# Altium schematic sheet VI.595X5.V1.1.SchDoc
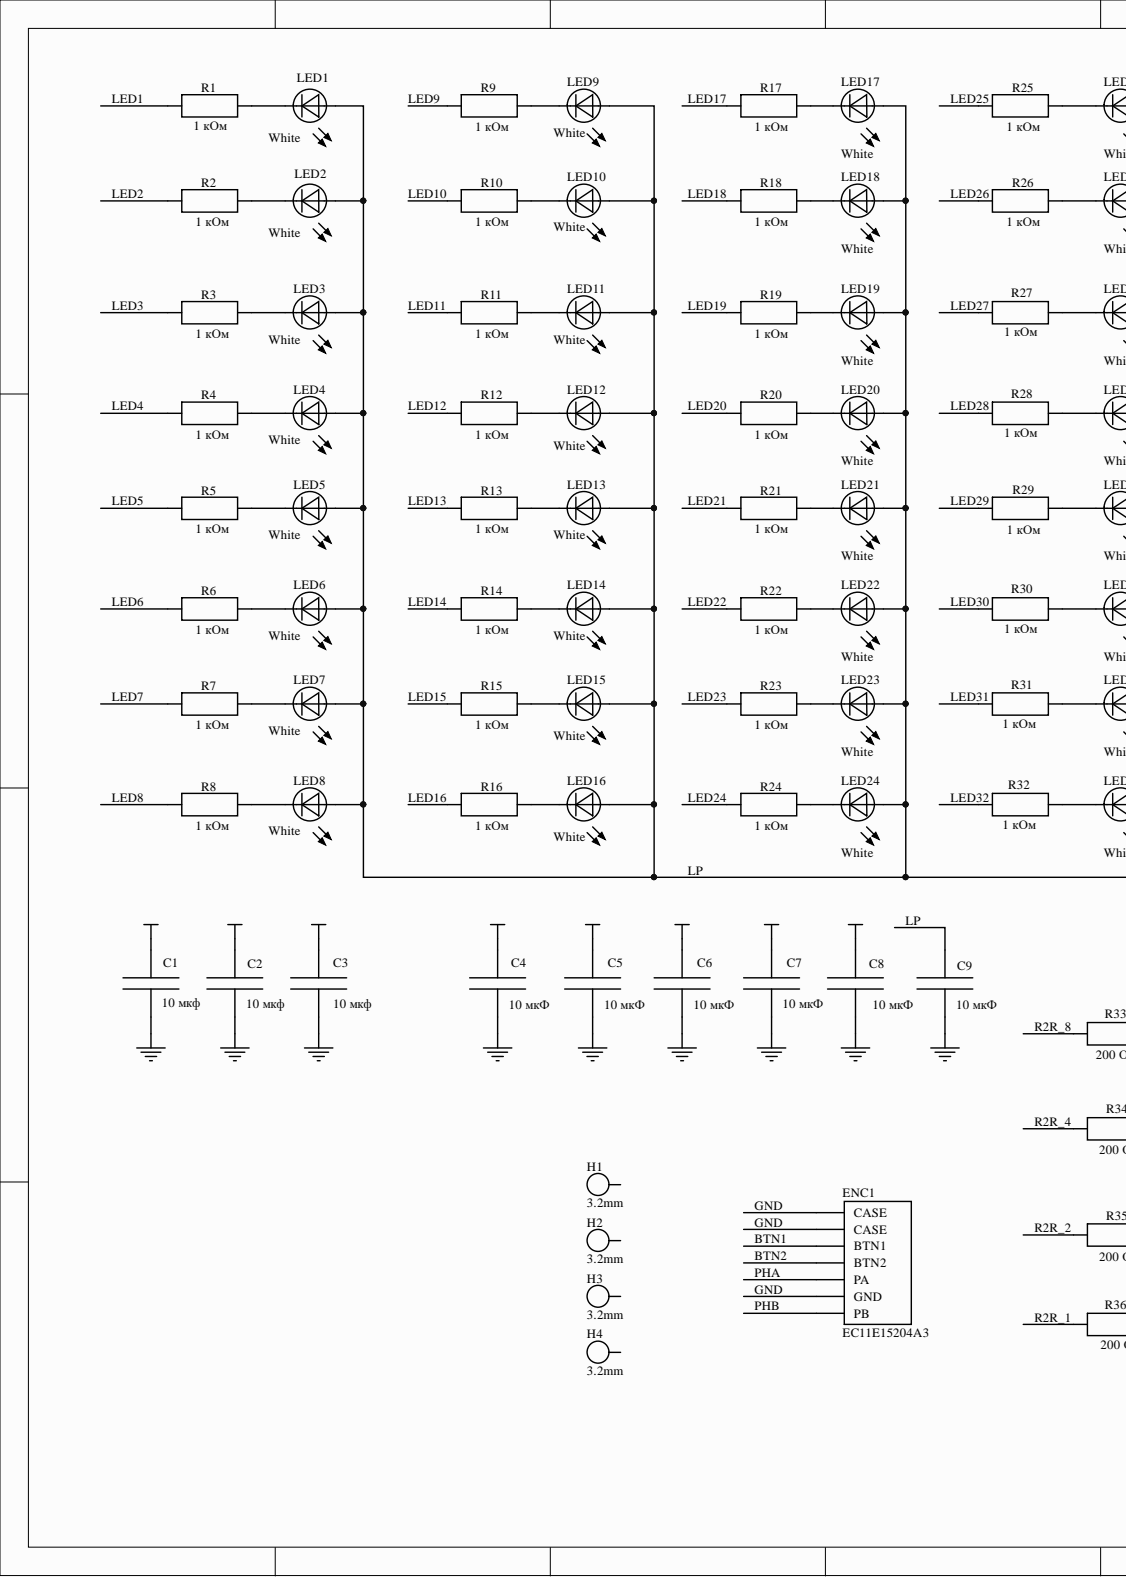
[diagram p0: VI.595X5.V1.1.SchDoc, left]
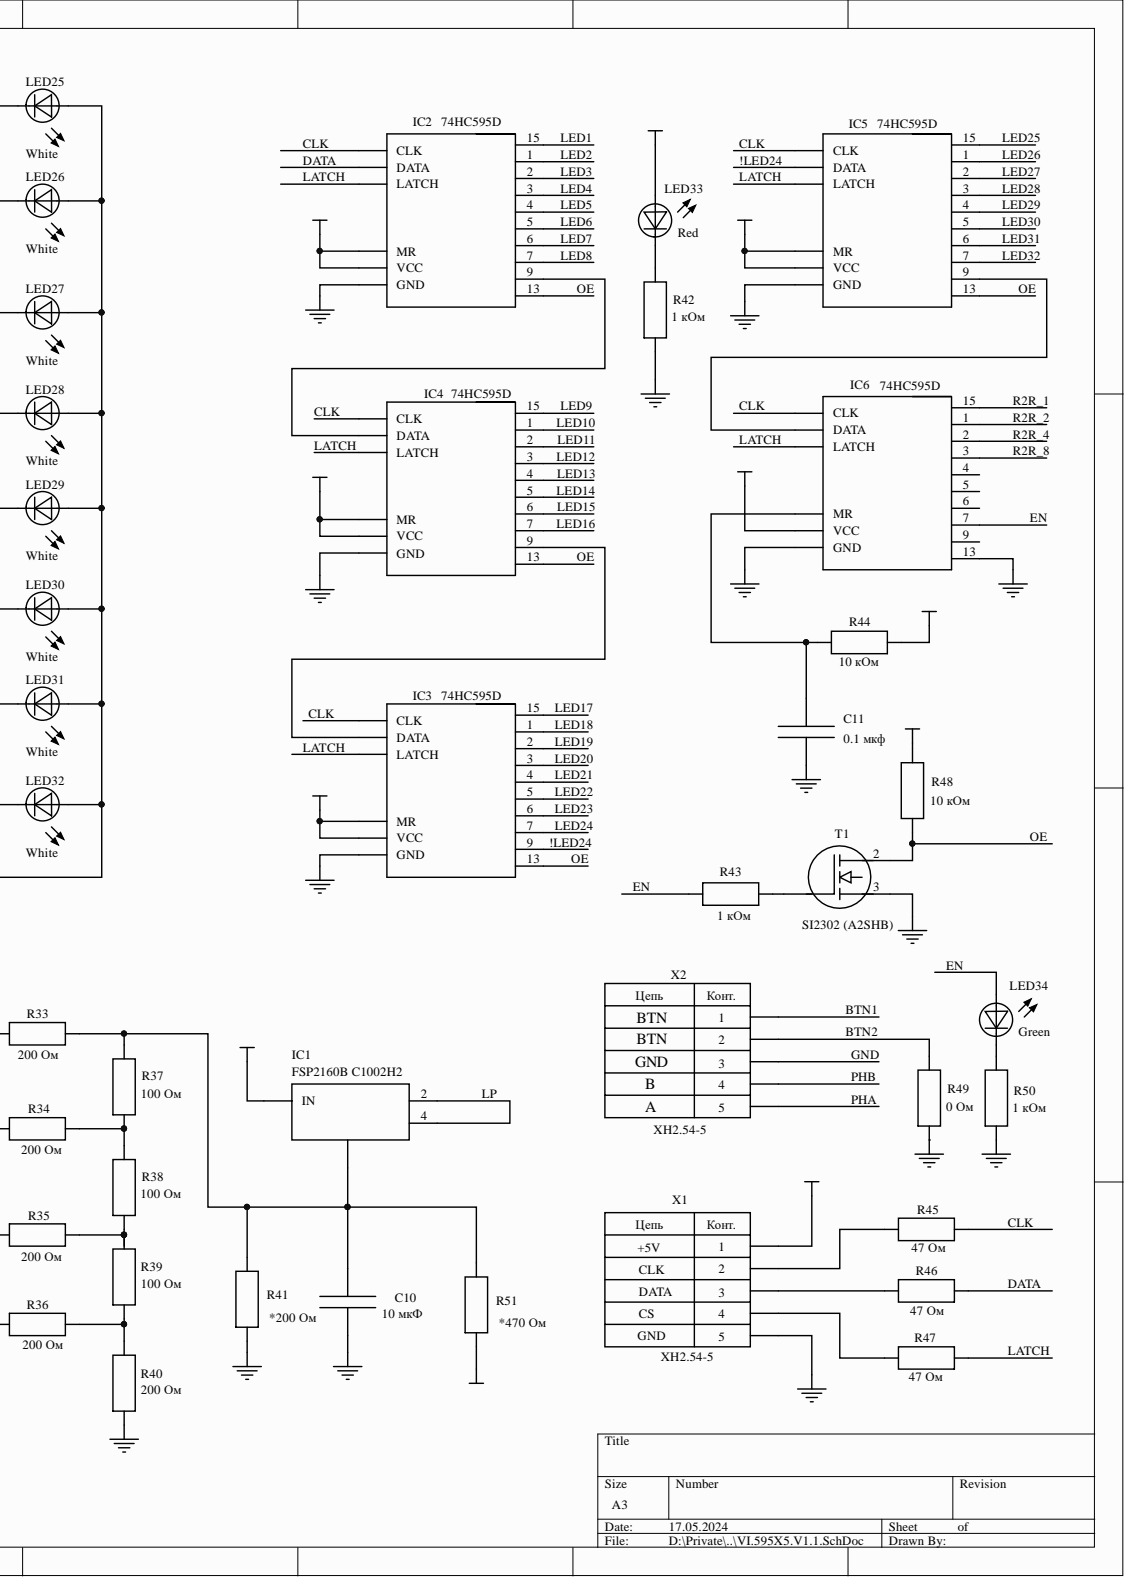
[diagram p1: VI.595X5.V1.1.SchDoc, right]

## Parts
C1  10 мкф  [Конденсатор SMD Case 1206]
C2  10 мкф  [Конденсатор SMD Case 1206]
C3  10 мкф  [Конденсатор SMD Case 1206]
C4  10 мкФ  [Конденсатор SMD Case 1206]
C5  10 мкФ  [Конденсатор SMD Case 1206]
C6  10 мкФ  [Конденсатор SMD Case 1206]
C7  10 мкФ  [Конденсатор SMD Case 1206]
C8  10 мкФ  [Конденсатор SMD Case 1206]
C9  10 мкФ  [Конденсатор SMD Case 1206]
C10  10 мкФ  [Конденсатор SMD Case 1206]
C11  0.1 мкф  [Конденсатор SMD Case 1206]
ENC1  EC11E15204A3  [Encoder]
H1  3.2mm  [Отверстие 3,2]
H2  3.2mm  [Отверстие 3,2]
H3  3.2mm  [Отверстие 3,2]
H4  3.2mm  [Отверстие 3,2]
IC1  FSP2160B C1002H2  [SOT-223]
IC2  74HC595D  [SO-16]
IC3  74HC595D  [SO-16]
IC4  74HC595D  [SO-16]
IC5  74HC595D  [SO-16]
IC6  74HC595D  [SO-16]
LED1  White  [Светодиод  5x2 mm]
LED2  White  [Светодиод  5x2 mm]
LED3  White  [Светодиод  5x2 mm]
LED4  White  [Светодиод  5x2 mm]
LED5  White  [Светодиод  5x2 mm]
LED6  White  [Светодиод  5x2 mm]
LED7  White  [Светодиод  5x2 mm]
LED8  White  [Светодиод  5x2 mm]
LED9  White  [Светодиод  5x2 mm]
LED10  White  [Светодиод  5x2 mm]
LED11  White  [Светодиод  5x2 mm]
LED12  White  [Светодиод  5x2 mm]
LED13  White  [Светодиод  5x2 mm]
LED14  White  [Светодиод  5x2 mm]
LED15  White  [Светодиод  5x2 mm]
LED16  White  [Светодиод  5x2 mm]
LED17  White  [Светодиод  5x2 mm]
LED18  White  [Светодиод  5x2 mm]
LED19  White  [Светодиод  5x2 mm]
LED20  White  [Светодиод  5x2 mm]
LED21  White  [Светодиод  5x2 mm]
LED22  White  [Светодиод  5x2 mm]
LED23  White  [Светодиод  5x2 mm]
LED24  White  [Светодиод  5x2 mm]
LED25  White  [Светодиод  5x2 mm]
LED26  White  [Светодиод  5x2 mm]
LED27  White  [Светодиод  5x2 mm]
LED28  White  [Светодиод  5x2 mm]
LED29  White  [Светодиод  5x2 mm]
LED30  White  [Светодиод  5x2 mm]
LED31  White  [Светодиод  5x2 mm]
LED32  White  [Светодиод  5x2 mm]
LED33  Red  [SMD 1206 Светодиод ВАЙТ]
LED34  Green  [SMD 1206 Светодиод ВАЙТ]
R1  1 кОм  [SMD 0805 Резистор]
R2  1 кОм  [SMD 0805 Резистор]
R3  1 кОм  [SMD 0805 Резистор]
R4  1 кОм  [SMD 0805 Резистор]
R5  1 кОм  [SMD 0805 Резистор]
R6  1 кОм  [SMD 0805 Резистор]
R7  1 кОм  [SMD 0805 Резистор]
R8  1 кОм  [SMD 0805 Резистор]
R9  1 кОм  [SMD 0805 Резистор]
R10  1 кОм  [SMD 0805 Резистор]
R11  1 кОм  [SMD 0805 Резистор]
R12  1 кОм  [SMD 0805 Резистор]
R13  1 кОм  [SMD 0805 Резистор]
R14  1 кОм  [SMD 0805 Резистор]
R15  1 кОм  [SMD 0805 Резистор]
R16  1 кОм  [SMD 0805 Резистор]
R17  1 кОм  [SMD 0805 Резистор]
R18  1 кОм  [SMD 0805 Резистор]
R19  1 кОм  [SMD 0805 Резистор]
R20  1 кОм  [SMD 0805 Резистор]
R21  1 кОм  [SMD 0805 Резистор]
R22  1 кОм  [SMD 0805 Резистор]
R23  1 кОм  [SMD 0805 Резистор]
R24  1 кОм  [SMD 0805 Резистор]
R25  1 кОм  [SMD 0805 Резистор]
R26  1 кОм  [SMD 0805 Резистор]
R27  1 кОм  [SMD 0805 Резистор]
R28  1 кОм  [SMD 0805 Резистор]
R29  1 кОм  [SMD 0805 Резистор]
R30  1 кОм  [SMD 0805 Резистор]
R31  1 кОм  [SMD 0805 Резистор]
R32  1 кОм  [SMD 0805 Резистор]
R33  200 Ом  [SMD 0805 Резистор]
R34  200 Ом  [SMD 0805 Резистор]
R35  200 Ом  [SMD 0805 Резистор]
R36  200 Ом  [SMD 0805 Резистор]
R37  100 Ом  [SMD 0805 Резистор]
R38  100 Ом  [SMD 0805 Резистор]
R39  100 Ом  [SMD 0805 Резистор]
R40  200 Ом  [SMD 0805 Резистор]
R41  *200 Ом  [SMD 0805 Резистор]
R42  1 кОм  [SMD 0805 Резистор]
R43  1 кОм  [SMD 0805 Резистор]
R44  10 кОм  [SMD 0805 Резистор]
R45  47 Ом  [SMD 0805 Резистор]
R46  47 Ом  [SMD 0805 Резистор]
R47  47 Ом  [SMD 0805 Резистор]
R48  10 кОм  [SMD 0805 Резистор]
R49  0 Ом  [SMD 0805 Резистор]
R50  1 кОм  [SMD 0805 Резистор]
R51  *470 Ом  [SMD 0805 Резистор]
T1  SI2302 (A2SHB)  [SMD SOT-23 Транзистор 3 pin]
X1  XH2.54-5  [(1x5)pin XH 2.54 Header]
X2  XH2.54-5  [(1x5)pin XH 2.54 Header]

## Nets
VCC: C1.1 C2.1 C3.1 C4.1 C5.1 C6.1 C7.1 C8.1 IC1.3(IN) IC2.10(MR) IC2.16(VCC) IC3.10(MR) IC3.16(VCC) IC4.10(MR) IC4.16(VCC) IC5.10(MR) IC5.16(VCC) IC6.16(VCC) LED33.1 R44.1 R48.1 R51.1 X1.1
!LED24: IC3.9 IC5.14(DATA)
BTN1: ENC1.3(BTN1) X2.1
BTN2: ENC1.4(BTN2) R49.2 X2.2
CLK: IC2.11(CLK) IC3.11(CLK) IC4.11(CLK) IC5.11(CLK) IC6.11(CLK) R45.1
DATA: IC2.14(DATA) R46.1
EN: IC6.7 LED34.1 R43.2
GND: C1.2 C2.2 C3.2 C4.2 C5.2 C6.2 C7.2 C8.2 C9.2 C10.2 C11.2 ENC1.1(CASE) ENC1.2(CASE) ENC1.6(GND) IC2.8(GND) IC3.8(GND) IC4.8(GND) IC5.8(GND) IC6.8(GND) IC6.13 R40.1 R41.1 R42.1 R49.1 R50.1 T1.3 X1.5 X2.3
LATCH: IC2.12(LATCH) IC3.12(LATCH) IC4.12(LATCH) IC5.12(LATCH) IC6.12(LATCH) R47.1
LED1: IC2.15 R1.1
LED2: IC2.1 R2.1
LED3: IC2.2 R3.1
LED4: IC2.3 R4.1
LED5: IC2.4 R5.1
LED6: IC2.5 R6.1
LED7: IC2.6 R7.1
LED8: IC2.7 R8.1
LED9: IC4.15 R9.1
LED10: IC4.1 R10.1
LED11: IC4.2 R11.1
LED12: IC4.3 R12.1
LED13: IC4.4 R13.1
LED14: IC4.5 R14.1
LED15: IC4.6 R15.1
LED16: IC4.7 R16.1
LED17: IC3.15 R17.1
LED18: IC3.1 R18.1
LED19: IC3.2 R19.1
LED20: IC3.3 R20.1
LED21: IC3.4 R21.1
LED22: IC3.5 R22.1
LED23: IC3.6 R23.1
LED24: IC3.7 R24.1
LED25: IC5.15 R25.1
LED26: IC5.1 R26.1
LED27: IC5.2 R27.1
LED28: IC5.3 R28.1
LED29: IC5.4 R29.1
LED30: IC5.5 R30.1
LED31: IC5.6 R31.1
LED32: IC5.7 R32.1
LP: C9.1 IC1.2 IC1.4 LED1.1 LED2.1 LED3.1 LED4.1 LED5.1 LED6.1 LED7.1 LED8.1 LED9.1 LED10.1 LED11.1 LED12.1 LED13.1 LED14.1 LED15.1 LED16.1 LED17.1 LED18.1 LED19.1 LED20.1 LED21.1 LED22.1 LED23.1 LED24.1 LED25.1 LED26.1 LED27.1 LED28.1 LED29.1 LED30.1 LED31.1 LED32.1
OE: IC2.13 IC3.13 IC4.13 IC5.13 R48.2 T1.2
PHA: ENC1.5(PA) X2.5
PHB: ENC1.7(PB) X2.4
R2R_1: IC6.15 R36.1
R2R_2: IC6.1 R35.1
R2R_4: IC6.2 R34.1
R2R_8: IC6.3 R33.1
NetC10_1: C10.1 IC1.1 R33.2 R37.2 R41.2 R51.2
NetC11_1: C11.1 IC6.10(MR) R44.2
NetIC2_9: IC2.9 IC4.14(DATA)
NetIC3_14: IC3.14(DATA) IC4.9
NetIC5_9: IC5.9 IC6.14(DATA)
NetLED1_2: LED1.2 R1.2
NetLED2_2: LED2.2 R2.2
NetLED3_2: LED3.2 R3.2
NetLED4_2: LED4.2 R4.2
NetLED5_2: LED5.2 R5.2
NetLED6_2: LED6.2 R6.2
NetLED7_2: LED7.2 R7.2
NetLED8_2: LED8.2 R8.2
NetLED9_2: LED9.2 R9.2
NetLED10_2: LED10.2 R10.2
NetLED11_2: LED11.2 R11.2
NetLED12_2: LED12.2 R12.2
NetLED13_2: LED13.2 R13.2
NetLED14_2: LED14.2 R14.2
NetLED15_2: LED15.2 R15.2
NetLED16_2: LED16.2 R16.2
NetLED17_2: LED17.2 R17.2
NetLED18_2: LED18.2 R18.2
NetLED19_2: LED19.2 R19.2
NetLED20_2: LED20.2 R20.2
NetLED21_2: LED21.2 R21.2
NetLED22_2: LED22.2 R22.2
NetLED23_2: LED23.2 R23.2
NetLED24_2: LED24.2 R24.2
NetLED25_2: LED25.2 R25.2
NetLED26_2: LED26.2 R26.2
NetLED27_2: LED27.2 R27.2
NetLED28_2: LED28.2 R28.2
NetLED29_2: LED29.2 R29.2
NetLED30_2: LED30.2 R30.2
NetLED31_2: LED31.2 R31.2
NetLED32_2: LED32.2 R32.2
NetLED33_2: LED33.2 R42.2
NetLED34_2: LED34.2 R50.2
NetR34_2: R34.2 R37.1 R38.2
NetR35_2: R35.2 R38.1 R39.2
NetR36_2: R36.2 R39.1 R40.2
NetR43_1: R43.1 T1.1
NetR45_2: R45.2 X1.2
NetR46_2: R46.2 X1.3
NetR47_2: R47.2 X1.4

Not connected: H1 1; H2 1; H3 1; H4 1; IC6 4 5 6 9

## Library data of the parts
C1-C11 = Конденсатор: Case: SMD 1206; Component Kind: Standard
  C1-C3: Library Name: S83.IntLib; Value: 10 мкф
  C4-C10: Library Name: S83.IntLib; Value: 10 мкФ
  C11: Library Name: S83.SchLib; Value: 0.1 мкф
ENC1 = Encoder_01: Case: -; Component Kind: Standard; Library Name: S83.IntLib; Value: EC11E15204A3
H1-H4 = Отверстие монтажное: Component Kind: Standard; Library Name: S83.IntLib; Value: 3.2mm
IC1 = FSP2160B J1003H1: Case: SOT-223; Component Kind: Standard; Library Name: S83_IC.IntLib; Value: FSP2160B C1002H2
LED1-LED34 = Светодиод: Component Kind: Standard
  LED1-LED32: Case: 5x2x6; Library Name: S83.SchLib; Value: White
  LED33: Case: SMD 1206; Library Name: S83.IntLib; Value: Red
  LED34: Case: SMD 1206; Library Name: S83.IntLib; Value: Green
R1-R51 = Резистор: Case: SMD 0805; Component Kind: Standard; Library Name: S83.SchLib
  R1-R32 R42 R43 R50: Value: 1 кОм
  R33-R36 R40: Value: 200 Ом
  R37-R39: Value: 100 Ом
  R41: Value: *200 Ом
  R44 R48: Value: 10 кОм
  R45-R47: Value: 47 Ом
  R49: Value: 0 Ом
  R51: Value: *470 Ом
T1 = Транзистор MOSFET N-конал (как n-p-n): Case: SOT-23; Component Kind: Standard; Library Name: S83.IntLib; Value: SI2302 (A2SHB)
X1 X2 = (1x5) pin 2.54 Header: Case: XH2.54-5; Component Kind: Standard; Library Name: S83_connectors.IntLib; Value: XH2.54-5
IC2-IC6 = 74HC595D: Case: SO-16; Component Kind: Standard; Library Name: S83_IC.IntLib; Value: 74HC595D
